# Altium schematic sheet UBX_NORA_B1_SCHEM_0001_NORA_B1_Module.SchDoc
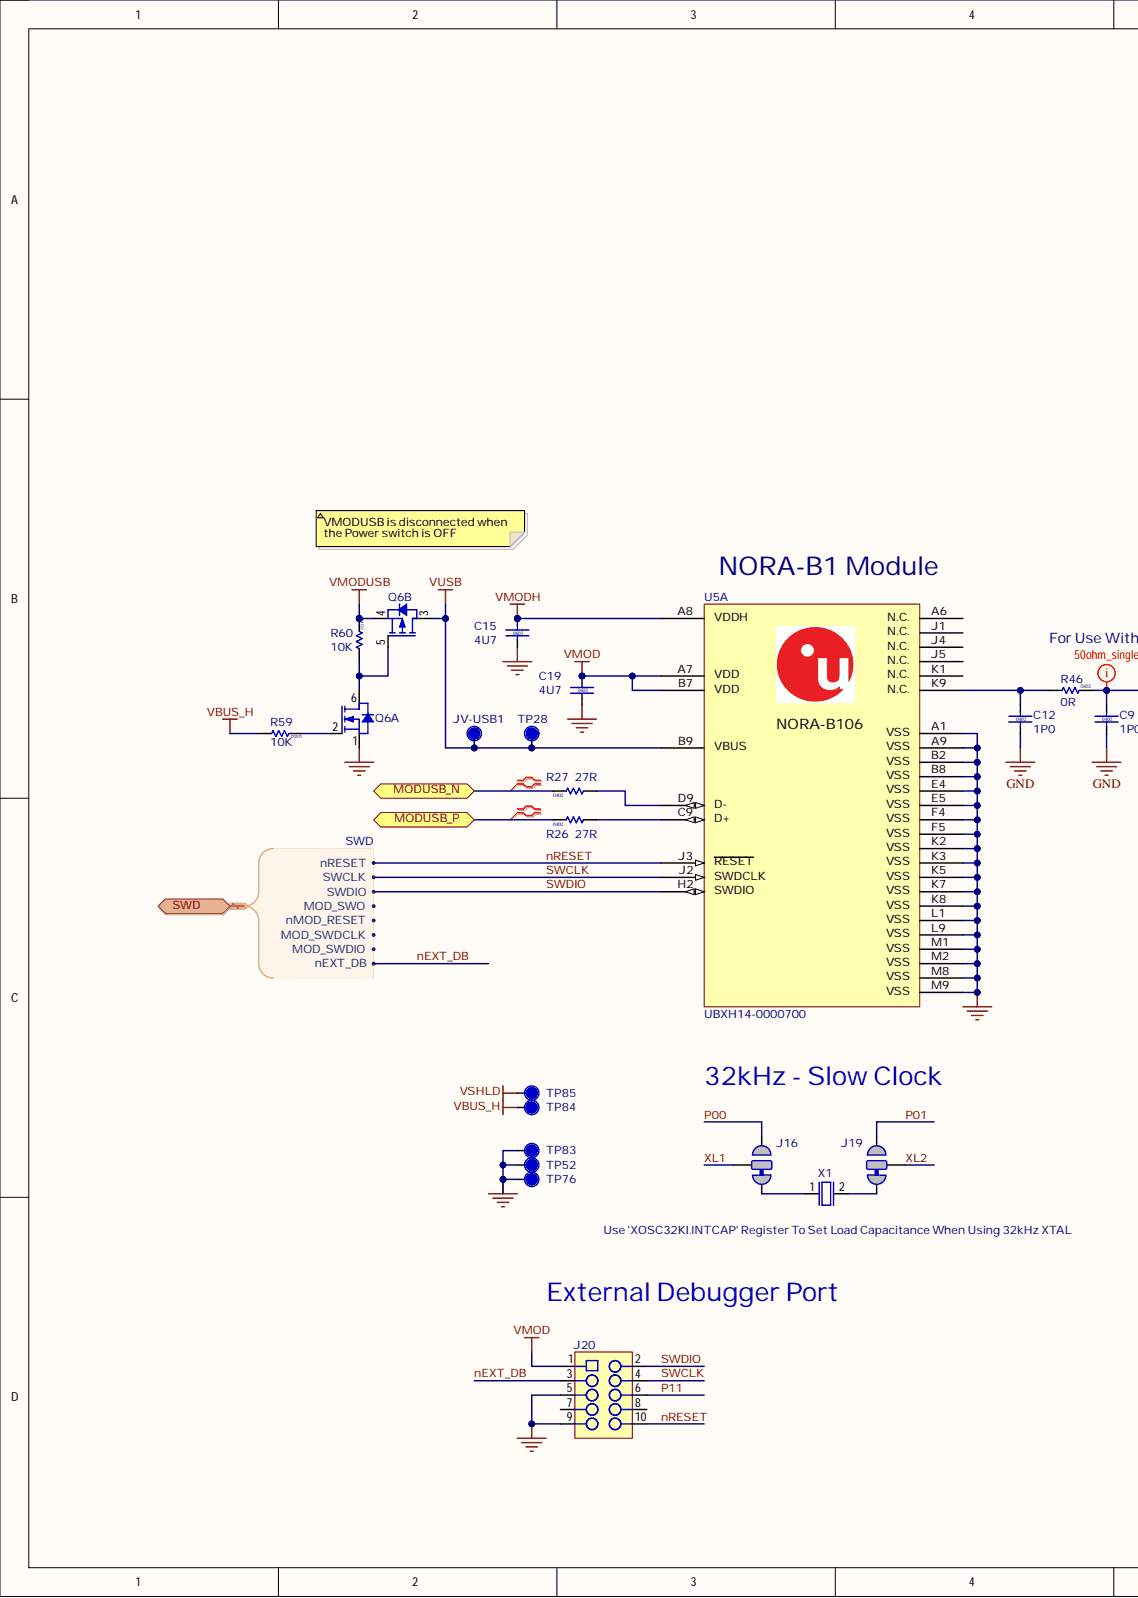
[diagram p0: UBX_NORA_B1_SCHEM_0001_NORA_B1_Module.SchDoc, left]
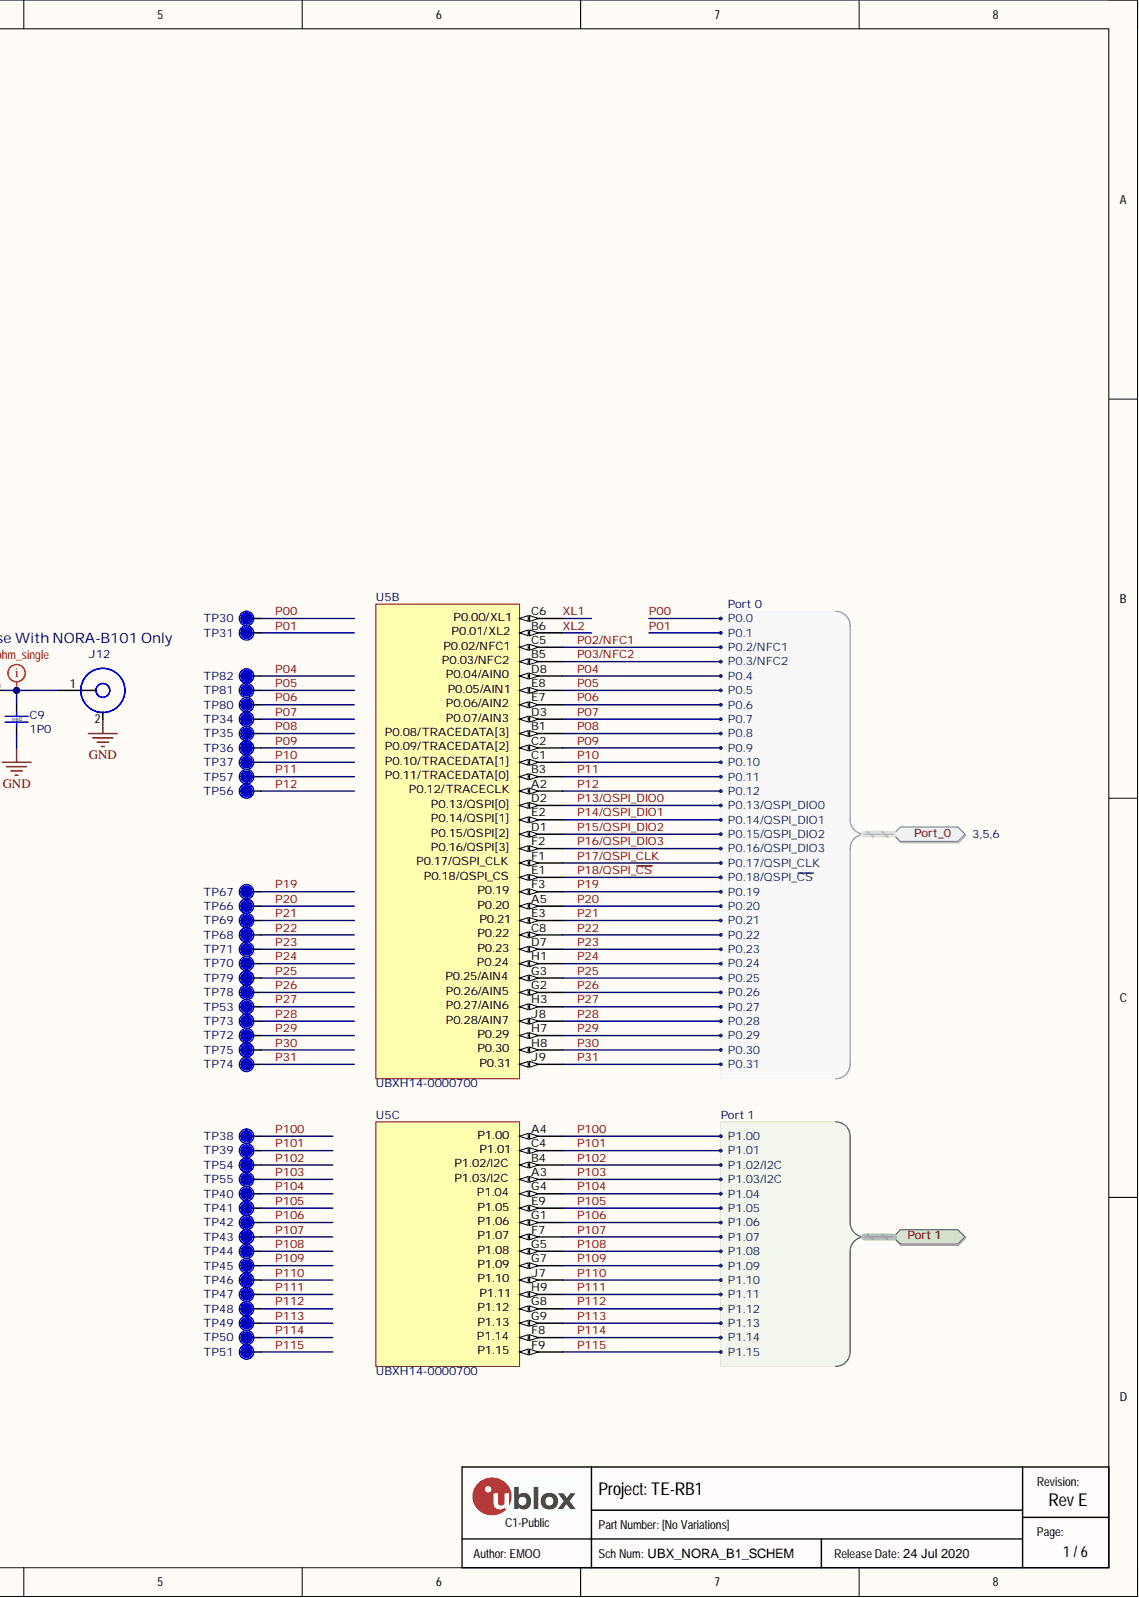
[diagram p1: UBX_NORA_B1_SCHEM_0001_NORA_B1_Module.SchDoc, right]

## Parts
C9  value 1P0  Size: 0402  [Cap 0402 HD]  (hidden comment: UBXH01-00090021P0)
C12  value 1P0  Size: 0402  [Cap 0402 HD]  (hidden comment: UBXH01-00090021P0)
C15  value 4U7  Size: 0603  [CAP 0603 HD]  (hidden comment: UBXH01-20020034U7)
C19  value 4U7  Size: 0603  [CAP 0603 HD]  (hidden comment: UBXH01-20020034U7)
J12  UBXH04-0000657  [U.FL_3Pin]  (value not drawn)
J16  UBXH00-0000083  [Solderbridge-Option]  (value not drawn)
J19  UBXH00-0000083  [Solderbridge-Option]  (value not drawn)
J20  UBXH04-0000194  [FTSH-105-01-F-DV-K]  (value not drawn)
JV-USB1  TP  [TP10R_NO_SILK]  (value not drawn)  (no BOM)
Q6  UBXH20-0000084  [SOT-563]  (value not drawn)  (parts A,B)
R26  value 27R  Size: 0402  [RES 0402 HD]  (hidden comment: UBXH18-000801127R)
R27  value 27R  Size: 0402  [RES 0402 HD]  (hidden comment: UBXH18-000801127R)
R46  value 0R  Size: 0402  [RES 0402 HD]  (hidden comment: UBXH18-00030020R)
R59  value 10K  Size: 0402  [RES 0402 HD]  (hidden comment: UBXH18-000006510K)
R60  value 10K  Size: 0402  [RES 0402 HD]  (hidden comment: UBXH18-000006510K)
TP28  TP  [TP10R_NO_SILK]  (no BOM)
TP30  TP  [TP10R_NO_SILK]  (no BOM)
TP31  TP  [TP10R_NO_SILK]  (no BOM)
TP34  TP  [TP10R_NO_SILK]  (no BOM)
TP35  TP  [TP10R_NO_SILK]  (no BOM)
TP36  TP  [TP10R_NO_SILK]  (no BOM)
TP37  TP  [TP10R_NO_SILK]  (no BOM)
TP38  TP  [TP10R_NO_SILK]
TP39  TP  [TP10R_NO_SILK]
TP40  TP  [TP10R_NO_SILK]
TP41  TP  [TP10R_NO_SILK]
TP42  TP  [TP10R_NO_SILK]
TP43  TP  [TP10R_NO_SILK]
TP44  TP  [TP10R_NO_SILK]
TP45  TP  [TP10R_NO_SILK]
TP46  TP  [TP10R_NO_SILK]
TP47  TP  [TP10R_NO_SILK]
TP48  TP  [TP10R_NO_SILK]
TP49  TP  [TP10R_NO_SILK]
TP50  TP  [TP10R_NO_SILK]
TP51  TP  [TP10R_NO_SILK]
TP52  TP  [TP10R_NO_SILK]  (no BOM)
TP53  TP  [TP10R_NO_SILK]
TP54  TP  [TP10R_NO_SILK]
TP55  TP  [TP10R_NO_SILK]
TP56  TP  [TP10R_NO_SILK]  (no BOM)
TP57  TP  [TP10R_NO_SILK]  (no BOM)
TP66  TP  [TP10R_NO_SILK]
TP67  TP  [TP10R_NO_SILK]
TP68  TP  [TP10R_NO_SILK]
TP69  TP  [TP10R_NO_SILK]
TP70  TP  [TP10R_NO_SILK]
TP71  TP  [TP10R_NO_SILK]
TP72  TP  [TP10R_NO_SILK]
TP73  TP  [TP10R_NO_SILK]
TP74  TP  [TP10R_NO_SILK]
TP75  TP  [TP10R_NO_SILK]
TP76  TP  [TP10R_NO_SILK]  (no BOM)
TP78  TP  [TP10R_NO_SILK]
TP79  TP  [TP10R_NO_SILK]
TP80  TP  [TP10R_NO_SILK]  (no BOM)
TP81  TP  [TP10R_NO_SILK]  (no BOM)
TP82  TP  [TP10R_NO_SILK]  (no BOM)
TP83  TP  [TP10R_NO_SILK]  (no BOM)
TP84  TP  [TP10R_NO_SILK]  (no BOM)
TP85  TP  [TP10R_NO_SILK]  (no BOM)
U5  UBXH14-0000700  Part_Type: Module  [NORA-B106]  (parts A,B,C)
X1  UBXH21-0000123  [XTAL_3215]  (value not drawn)

## Ports
MODUSB_N, MODUSB_P, Port 1, Port 1.P1.00, Port 1.P1.01, Port 1.P1.02/I2C, Port 1.P1.03/I2C, Port 1.P1.04, Port 1.P1.05, Port 1.P1.06, Port 1.P1.07, Port 1.P1.08, Port 1.P1.09, Port 1.P1.10, Port 1.P1.11, Port 1.P1.12, Port 1.P1.13, Port 1.P1.14, Port 1.P1.15, Port_0, Port_0.P0.0, Port_0.P0.1, Port_0.P0.2/NFC1, Port_0.P0.3/NFC2, Port_0.P0.4, Port_0.P0.5, Port_0.P0.6, Port_0.P0.7, Port_0.P0.8, Port_0.P0.9, Port_0.P0.10, Port_0.P0.11, Port_0.P0.12, Port_0.P0.13/QSPI_DIO0, Port_0.P0.14/QSPI_DIO1, Port_0.P0.15/QSPI_DIO2, Port_0.P0.16/QSPI_DIO3, Port_0.P0.17/QSPI_CLK, Port_0.P0.18/QSPI_~{CS}, Port_0.P0.19, Port_0.P0.20, Port_0.P0.21, Port_0.P0.22, Port_0.P0.23, Port_0.P0.24, Port_0.P0.25, Port_0.P0.26, Port_0.P0.27, Port_0.P0.28, Port_0.P0.29, Port_0.P0.30, Port_0.P0.31, SWD, SWD.MOD_SWDCLK, SWD.MOD_SWDIO, SWD.MOD_SWO, SWD.nEXT_DB, SWD.nMOD_RESET, SWD.nRESET, SWD.SWCLK, SWD.SWDIO

## Nets
GND: C9.2 C12.2 C15.2 C19.2 J12.2 J20.5 J20.9 Q6.1 TP52.1 TP76.1 TP83.1 U5.A1(VSS) U5.A9(VSS) U5.B2(VSS) U5.B8(VSS) U5.E4(VSS) U5.E5(VSS) U5.F4(VSS) U5.F5(VSS) U5.K2(VSS) U5.K3(VSS) U5.K5(VSS) U5.K7(VSS) U5.K8(VSS) U5.L1(VSS) U5.L9(VSS) U5.M1(VSS) U5.M2(VSS) U5.M8(VSS) U5.M9(VSS)
VBUS_H: R59.1 TP84.1
VMOD: C19.1 J20.1 U5.A7(VDD) U5.B7(VDD)
VMODH: C15.1 U5.A8(VDDH)
VMODUSB: Q6.4 R60.2
VSHLD: TP85.1
VUSB: JV-USB1.1 Q6.3 TP28.1 U5.B9(VBUS)
MODUSB_N: R27.2 ; port MODUSB_N
MODUSB_P: R26.2 ; port MODUSB_P
nEXT_DB: J20.3 ; port SWD
nRESET: J20.10 U5.J3(RESET) ; port SWD
P00: J16.3 TP30.1 ; port Port_0
P01: J19.3 TP31.1 ; port Port_0
P02/NFC1: U5.C5(P0.02/NFC1) ; port Port_0
P03/NFC2: U5.B5(P0.03/NFC2) ; port Port_0
P04: TP82.1 U5.D8(P0.04/AIN0) ; port Port_0
P05: TP81.1 U5.E8(P0.05/AIN1) ; port Port_0
P06: TP80.1 U5.E7(P0.06/AIN2) ; port Port_0
P07: TP34.1 U5.D3(P0.07/AIN3) ; port Port_0
P08: TP35.1 U5.B1(P0.08/TRACEDATA[3]) ; port Port_0
P09: TP36.1 U5.C2(P0.09/TRACEDATA[2]) ; port Port_0
P10: TP37.1 U5.C1(P0.10/TRACEDATA[1]) ; port Port_0
P11: J20.6 TP57.1 U5.B3(P0.11/TRACEDATA[0]) ; port Port_0
P12: TP56.1 U5.A2(P0.12/TRACECLK) ; port Port_0
P13/QSPI_DIO0: U5.D2(P0.13/QSPI[0]) ; port Port_0
P14/QSPI_DIO1: U5.E2(P0.14/QSPI[1]) ; port Port_0
P15/QSPI_DIO2: U5.D1(P0.15/QSPI[2]) ; port Port_0
P16/QSPI_DIO3: U5.F2(P0.16/QSPI[3]) ; port Port_0
P17/QSPI_CLK: U5.F1(P0.17/QSPI_CLK) ; port Port_0
P18/QSPI_~{CS}: U5.E1(P0.18/QSPI_CS) ; port Port_0
P19: TP67.1 U5.F3(P0.19) ; port Port_0
P20: TP66.1 U5.A5(P0.20) ; port Port_0
P21: TP69.1 U5.E3(P0.21) ; port Port_0
P22: TP68.1 U5.C8(P0.22) ; port Port_0
P23: TP71.1 U5.D7(P0.23) ; port Port_0
P24: TP70.1 U5.H1(P0.24) ; port Port_0
P25: TP79.1 U5.G3(P0.25/AIN4) ; port Port_0
P26: TP78.1 U5.G2(P0.26/AIN5) ; port Port_0
P27: TP53.1 U5.H3(P0.27/AIN6) ; port Port_0
P28: TP73.1 U5.J8(P0.28/AIN7) ; port Port_0
P29: TP72.1 U5.H7(P0.29) ; port Port_0
P30: TP75.1 U5.H8(P0.30) ; port Port_0
P31: TP74.1 U5.J9(P0.31) ; port Port_0
P100: TP38.1 U5.A4(P1.00) ; port Port 1
P101: TP39.1 U5.C4(P1.01) ; port Port 1
P102: TP54.1 U5.B4(P1.02/I2C) ; port Port 1
P103: TP55.1 U5.A3(P1.03/I2C) ; port Port 1
P104: TP40.1 U5.G4(P1.04) ; port Port 1
P105: TP41.1 U5.E9(P1.05) ; port Port 1
P106: TP42.1 U5.G1(P1.06) ; port Port 1
P107: TP43.1 U5.F7(P1.07) ; port Port 1
P108: TP44.1 U5.G5(P1.08) ; port Port 1
P109: TP45.1 U5.G7(P1.09) ; port Port 1
P110: TP46.1 U5.J7(P1.10) ; port Port 1
P111: TP47.1 U5.H9(P1.11) ; port Port 1
P112: TP48.1 U5.G8(P1.12) ; port Port 1
P113: TP49.1 U5.G9(P1.13) ; port Port 1
P114: TP50.1 U5.F8(P1.14) ; port Port 1
P115: TP51.1 U5.F9(P1.15) ; port Port 1
SWCLK: J20.4 U5.J2(SWDCLK) ; port SWD
SWDIO: J20.2 U5.H2(SWDIO) ; port SWD
XL1: J16.2 U5.C6(P0.00/XL1)
XL2: J19.2 U5.B6(P0.01/XL2)
NetC9_1: C9.1 J12.1 R46.2
NetC12_1: C12.1 R46.1 U5.K9(N.C.)
NetJ16_1: J16.1 X1.1
NetJ19_1: J19.1 X1.2
NetQ6_2: Q6.2 R59.2
NetQ6_5: Q6.5 Q6.6 R60.1
NetR26_1: R26.1 U5.C9(D+)
NetR27_1: R27.1 U5.D9(D-)
N00001: ; port SWD
N00002: ; port SWD
N00003: ; port SWD
N00004: ; port SWD

Not connected: J20 7 8; U5 A6(N.C.) J1(N.C.) J4(N.C.) J5(N.C.) K1(N.C.)

## Library data of the parts
C9 C12 = UBXH01-0009002: CAP CER C0G 0402 1P0 +/-0.1P 50V; Manufacturer: Murata Manufacturing Company, Ltd.; Manufacturer P/N: GRM1555C1H1R0BA01; Database Table Name: Passive - Capacitor; Editor: massimo.vlacci; Env: ROHS; Mfg P/N Released: Yes; Mfg P/N Split: 100; Mfg P/N Status: NRND; Perferred: PREF; Permitted Use: Volume Products; Released by: sandro.sestan; Component Kind: Standard; Library Name: UBX_Parts_DB_Lib.DbLib; Manufacturer 2: Murata Manufacturing Company, Ltd.; Manufacturer P/N 2: GRM1555C1H1R0BZ01; Max Operating Temp: 125°C; Max Voltage: 50V; Mfg P/N Released 2: No; Mfg P/N Split 2: 0; Mfg P/N Status 2: Obsolete; Min Operating Temp: -55°C; Part Number: UBXH01-0009002; Part Type: Capacitor; RefDes: C; Release Data: 2010-02-19T10:36:53; Signal Integrity: Cap Semi; Simulation: CAP; Tolerance: +/-0.1P; UBX Wiki: https://wiki.u-blox.com/bin/view/Operations/PartDetails?index=5431
C15 C19 = UBXH01-2002003: CAP CER X5R 0603 4U7 10% 6.3V; Manufacturer: Murata Manufacturing Company, Ltd.; Manufacturer P/N: GRM188R61C475KE11; Database Table Name: Passive - Capacitor; Editor: philippe.largier; Env: GREEN; Mfg P/N Released: Yes; Mfg P/N Split: 100; Mfg P/N Status: Active; Perferred: PREF; Permitted Use: Volume Products; Released by: roland.rust; Component Kind: Standard; Library Name: UBX_Parts_DB_Lib.DbLib; Manufacturer 2: Murata Manufacturing Company, Ltd.; Manufacturer 3: Samsung Electro-Mechanics; Manufacturer P/N 2: GRM188R61E475KE11; Manufacturer P/N 3: CL10A475KO8NNN; Max Voltage: 6.3V; Mfg P/N Datasheet: https://wiki.u-blox.com/bin/view/Generic/Docs/DocumentSummary?index=30154; Mfg P/N Datasheet 2: https://wiki.u-blox.com/bin/view/Generic/Docs/DocumentSummary?index=30500; Mfg P/N Datasheet 3: https://wiki.u-blox.com/bin/view/Generic/Docs/DocumentSummary?index=28177; Mfg P/N Released 2: Yes; Mfg P/N Released 3: Yes; Mfg P/N Split 2: 0; Mfg P/N Split 3: 0; Mfg P/N Status 2: Active; Mfg P/N Status 3: NRND; Part Number: UBXH01-2002003; Part Type: Capacitor; RefDes: C; Release Data: 2016-02-02T16:39:45; Signal Integrity: Cap Semi; Simulation: CAP; Tolerance: 10%; UBX Wiki: https://wiki.u-blox.com/bin/view/Operations/PartDetails?index=5742
J12 = UBXH04-0000657: CONN U.FL STRAIGHT RECEPTACLE; Manufacturer: Hirose; Manufacturer P/N: U.FL-R-SMT-1(80); Database Table Name: Electromechanical - Connector; Editor: cam.nichols; Env: GREEN; Mfg P/N Released: No; Mfg P/N Split: 100; Mfg P/N Status: Active; Perferred: PREF; Permitted Use: Volume Products; Released by: eric.moog; Value: U.FL-R-SMT-1(80); Component Kind: Standard; Library Name: UBX_Parts_DB_Lib.DbLib; Manufacturer 2: Toptek; Manufacturer P/N 2: AB02001-126; Max Operating Temp: 105°C; Mfg P/N Datasheet: https://wiki.u-blox.com/bin/view/Generic/Docs/DocumentSummary?index=32065; Mfg P/N Datasheet 2: https://wiki.u-blox.com/bin/view/Generic/Docs/DocumentSummary?index=33337; Mfg P/N Released 2: No; Mfg P/N Split 2: 0; Mfg P/N Status 2: Active; Min Operating Temp: -40°C; Part Number: UBXH04-0000657; Part Type: Connector; RefDes: J; Release Data: 2020-09-04T22:33:09; UBX Wiki: https://wiki.u-blox.com/bin/view/Operations/PartDetails?index=33335
J16 J19 = UBXH00-0000083: SOLDERBRIDGE-OPTION; Database Table Name: Printed Circuit Element - Connector; Editor: aneeb.sohail; Env: GREEN; Perferred: PREF; Permitted Use: Volume Products; Component Kind: Net Tie; Library Name: UBX_Parts_DB_Lib.DbLib; Max Operating Temp: 155°C; Min Operating Temp: -55°C; Part Number: UBXH00-0000083; Part Type: Connector; RefDes: J; UBX Wiki: https://wiki.u-blox.com/bin/view/Operations/PartDetails?index=36362
J20 = UBXH04-0000194: 2-ROW SMD-PCB CONN 50MIL GRID 10 -PIN 0.41MM SQUARE 4.0MM KEYED; Manufacturer: Samtec; Manufacturer P/N: FTSH-105-01-L-DV-K; Database Table Name: Electromechanical - Connector; Editor: par.hakansson; Env: ROHS; Mfg P/N Released: Yes; Mfg P/N Split: 100; Mfg P/N Status: Active; Perferred: PREF; Permitted Use: Volume Products; Released by: adam.johansson; Value: FTSH-105-01-L-DV-K; Component Kind: Standard; Library Name: UBX_Parts_DB_Lib.DbLib; Max Operating Temp: 85°C; Mfg P/N Datasheet: https://wiki.u-blox.com/bin/view/Generic/Docs/DocumentSummary?index=20824; Min Operating Temp: -40°C; Part Number: UBXH04-0000194; Part Type: Connector; RefDes: J; Release Data: 2015-11-06T11:35:39; UBX Wiki: https://wiki.u-blox.com/bin/view/Operations/PartDetails?index=20823
JV-USB1 TP28 TP30 TP31 TP34-TP57 TP66-TP76 TP78-TP85 = UBXH00-0000096: TESTPOINT 1MM CIRCULAR NO SILKSCREEN; Database Table Name: Printed Circuit Element - Testpoint; Editor: eric.moog; Perferred: PREF; Permitted Use: Volume Products; Env: N/A; Library Name: UBX_Parts_DB_Lib.DbLib; Max Operating Temp: 125°C; Min Operating Temp: -55°C; Part Number: UBXH00-0000096; Part Type: Testpoint; RefDes: TP; UBX Wiki: https://wiki.u-blox.com/bin/view/Operations/PartDetails?index=38784
  TP38-TP51 TP53-TP55 TP66-TP75 TP78 TP79: Component Kind: Standard
Q6 = UBXH20-0000084: SMALL SIGNAL COMPLEMENTARY W/ ESD PROTECTION MOSFET SOT-563-6 PACKAGE; Manufacturer: ON Semiconductor; Manufacturer P/N: NTZD3155CT1G; Database Table Name: Discrete - Transistor; Editor: pierpaolo.arban; Env: ROHS; Mfg P/N Released: Yes; Mfg P/N Split: 100; Mfg P/N Status: Active; Perferred: PREF; Permitted Use: Support Products; Released by: matej.budin; Value: NTZD3155CT1G; Component Kind: Standard; Library Name: UBX_Parts_DB_Lib.DbLib; Max Operating Temp: 155°C; Mfg P/N Datasheet: https://wiki.u-blox.com/bin/view/Generic/Docs/DocumentSummary?index=9037; Min Operating Temp: -55°C; Part Number: UBXH20-0000084; Part Type: Transistor; RefDes: Q; Release Data: 2023-01-26T11:59:16; UBX Wiki: https://wiki.u-blox.com/bin/view/Operations/PartDetails?index=37717
R26 R27 = UBXH18-0008011: RES THICK FILM CHIP 0402 27R 5% 100mW; Manufacturer: KOA; Manufacturer P/N: RK73B1ETTD270J; Database Table Name: Passive - Resistor; Editor: roland.rust; Env: ROHS; Mfg P/N Released: Yes; Mfg P/N Split: 100; Mfg P/N Status: NRND; Perferred: PREF; Permitted Use: Volume Products; Component Kind: Standard; Library Name: UBX_Parts_DB_Lib.DbLib; Manufacturer 2: YAGEO; Manufacturer P/N 2: 2322_705_70279_L; Max Power: 100mW; Mfg P/N Released 2: Yes; Mfg P/N Split 2: 0; Mfg P/N Status 2: Obsolete; Part Number: UBXH18-0008011; Part Type: Resistor; RefDes: R; Release Data: 2005-07-22T13:59:44; Signal Integrity: Res; Simulation: RESISTOR; Tolerance: 5%; UBX Wiki: https://wiki.u-blox.com/bin/view/Operations/PartDetails?index=6883; Released by: roland.rust
R46 = UBXH18-0003002: RES THICK FILM CHIP 0402 0R 0 100mW; Manufacturer: KOA; Manufacturer P/N: RK73Z1ETTD; Database Table Name: Passive - Resistor; Editor: charlotte.biber; Env: ROHS; Mfg P/N Released: Yes; Mfg P/N Split: 100; Mfg P/N Status: NRND; Perferred: PREF; Permitted Use: Volume Products; Released by: peter.mueller; Tolerance: 0; Component Kind: Standard; Library Name: UBX_Parts_DB_Lib.DbLib; Manufacturer 2: YAGEO; Manufacturer P/N 2: 2322_705_91001_L; Max Power: 100mW; Mfg P/N Released 2: Yes; Mfg P/N Split 2: 0; Mfg P/N Status 2: NRND; Part Number: UBXH18-0003002; Part Type: Resistor; RefDes: R; Release Data: 2012-06-05T09:49:55; Signal Integrity: Res; Simulation: RESISTOR; UBX Wiki: https://wiki.u-blox.com/bin/view/Operations/PartDetails?index=6611
R59 R60 = UBXH18-0000065: RES THICK FILM CHIP 0402 10K 1% 63mW; Manufacturer: KOA; Manufacturer P/N: RK73H1ETTP1002F; Database Table Name: Passive - Resistor; Editor: erian.bezhani; Env: ROHS; Mfg P/N Released: Yes; Mfg P/N Split: 100; Mfg P/N Status: Active; Perferred: PREF; Permitted Use: Volume Products; Released by: massimo.vlacci; Component Kind: Standard; Library Name: UBX_Parts_DB_Lib.DbLib; Max Power: 63mW; Mfg P/N Datasheet: https://wiki.u-blox.com/bin/view/Generic/Docs/DocumentSummary?index=8222; Part Number: UBXH18-0000065; Part Type: Resistor; RefDes: R; Release Data: 2012-06-08T18:02:21; Signal Integrity: Res; Simulation: RESISTOR; Tolerance: 1%; UBX Wiki: https://wiki.u-blox.com/bin/view/Operations/PartDetails?index=16837
U5 = UBXH14-0000700: SHORT RANGE BLE AND 802.15.4 MODULE U-BLOX NORA-B106 5.5V 10mA; Manufacturer P/N: NORA-B106-00B-00; Manufacturer Part Number 1: NORA-B106-00B; Body Size: 14.3mm x 10.4mm; Component Mounting Type: SMT; Core Architecture: Dual Cortex-M33; Database Table Name: Module - RF; Editor: eric.moog; Env: ROHS; Flash Size: 1MB; Internal Antenna: Yes; Max Oper Temp in C: +105; Mfg P/N Released: No; Mfg P/N Split: 100; Mfg P/N Status: Active; MFG Package: NORA-82; Min Oper Temp in C: -40; Perferred: PREF; Permitted Use: Support Products; RF Frequency: 2.4GHZ; SMT Pitch: 1.1mm; Value: NORA-B106-00B-00; Component Kind: Standard; ComponentLink1Description: Datasheet; ComponentLink2Description: Supplier link; Height: 1.8mm; Library Name: UBX_Parts_DB_Lib.DbLib; Manufacturer: u-blox; Manufacturer 1: u-blox; Max Current: 10mA; Max Operating Temp: 105°C; Max Voltage: 5.5V; Mfg P/N Datasheet: https://wiki.u-blox.com/bin/view/Generic/Docs/DocumentSummary?index=38876; Min Operating Temp: -40°C; Package Type: LGA; Part Name: NORA-B106; Part Number: UBXH14-0000700; Part Type: RF; Pins: 82; RefDes: A; UBX Wiki: https://wiki.u-blox.com/bin/view/Operations/PartDetails?index=38882; Use in new designs: Yes; Voltage: 5.5V
X1 = UBXH21-0000123: CRYSTAL CL=6PF 3.2 X 1.5 MM 32.768KHZ 20PPM; Manufacturer: ABRACON; Manufacturer P/N: ABS07-120-32.768KHZ-T; Database Table Name: Passive - Crystal; Editor: martin.grasse; Env: ROHS; Mfg P/N Released: No; Mfg P/N Split: 100; Mfg P/N Status: NRND; Perferred: PREF; Permitted Use: Support Products; Component Kind: Standard; Library Name: UBX_Parts_DB_Lib.DbLib; Mfg P/N Datasheet: https://wiki.u-blox.com/bin/view/Generic/Docs/DocumentSummary?index=27621; Part Number: UBXH21-0000123; Part Type: Crystal; RefDes: Y; Tolerance: 20PPM; UBX Wiki: https://wiki.u-blox.com/bin/view/Operations/PartDetails?index=27619; Value: 32.768KHZ
